# Altium schematic sheet RS232 Interface.SchDoc
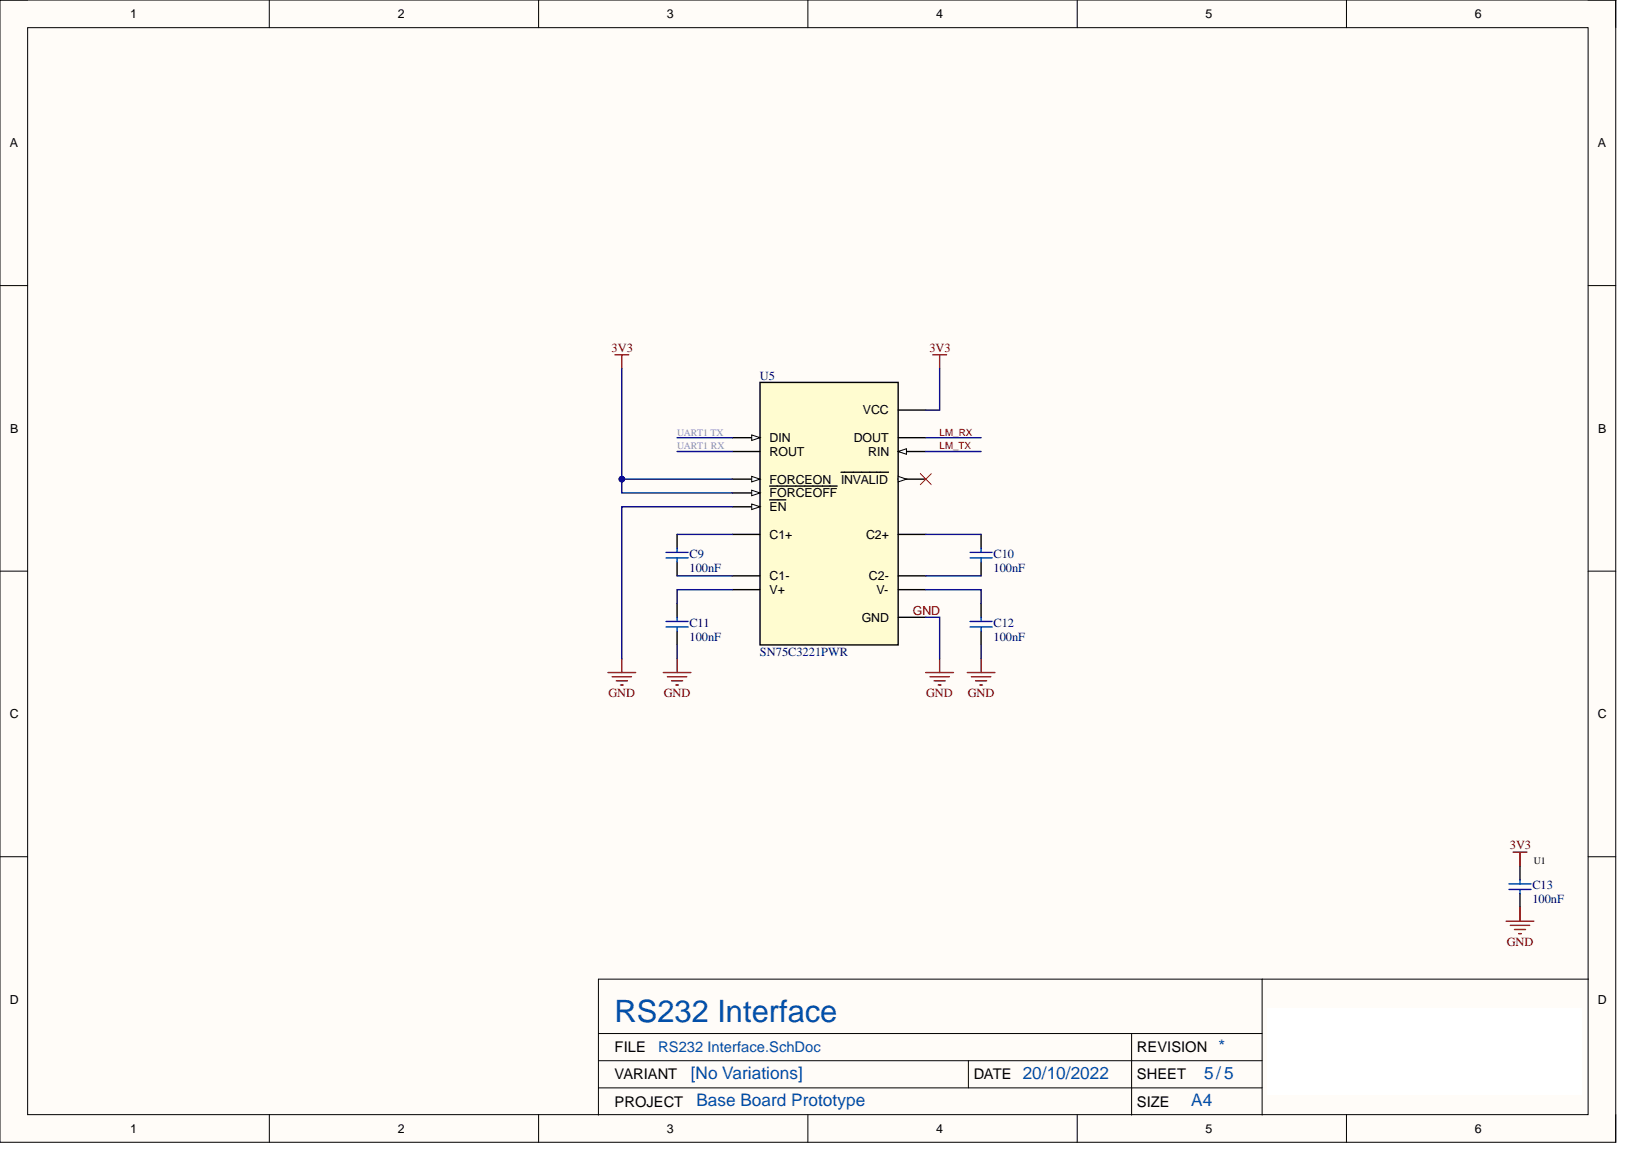
[diagram p0: RS232 Interface.SchDoc]

## Parts
C9  value 100nF  Capacitance: 100nF  [FP-GRM188-0_1-e0_2_0_5-IPC_B]  (hidden comment: C0603-100nF-100V-10%-X7R)
C10  value 100nF  Capacitance: 100nF  [FP-GRM188-0_1-e0_2_0_5-IPC_B]  (hidden comment: C0603-100nF-100V-10%-X7R)
C11  value 100nF  Capacitance: 100nF  [FP-GRM188-0_1-e0_2_0_5-IPC_B]  (hidden comment: C0603-100nF-100V-10%-X7R)
C12  value 100nF  Capacitance: 100nF  [FP-GRM188-0_1-e0_2_0_5-IPC_B]  (hidden comment: C0603-100nF-100V-10%-X7R)
C13  value 100nF  Capacitance: 100nF  [FP-GRM188-0_1-e0_2_0_5-IPC_B]  (hidden comment: C0603-100nF-100V-10%-X7R)
U5  SN75C3221PWR  [PW0016A_N]

## Nets
3V3: C13.2 U5.12(FORCEON) U5.15(VCC) U5.16(FORCEOFF)
GND: C11.1 C12.1 C13.1 U5.1(EN) U5.14(GND)
LM_RX: U5.13(DOUT)
LM_TX: U5.8(RIN)
UART1 RX: U5.9(ROUT)
UART1 TX: U5.11(DIN)
NetC9_1: C9.1 U5.4(C1-)
NetC9_2: C9.2 U5.2(C1+)
NetC10_1: C10.1 U5.6(C2-)
NetC10_2: C10.2 U5.5(C2+)
NetC11_2: C11.2 U5.3(V+)
NetC12_2: C12.2 U5.7(V-)

Not connected: U5 10(INVALID)

## Library data of the parts
C9-C13 = CMP-611-0027-15: 0.1µF ±10% 100V Ceramic Capacitor X7R 0603 (1608 Metric); Pick&PlaceFootprint: 0603_CAP; Capacitor Type: Ceramic; Case Code (Imperial): 0603; Case Code (Metric): 1608; Case/Package: 0603; Component Kind: Standard; Construction: Flat; Depth: 0.8mm; Dielectric: X7R; Height: 0.8mm; Lead Free: Lead Free; Length: 1.6mm; Material: Ceramic; Max Operating Temperature: 125°C; Min Operating Temperature: -55°C; Mount: Surface Mount; Package Quantity: 1; Packaging: Tape and Reel; Radiation Hardening: No; REACH SVHC: No SVHC; RoHS: Yes; RoHS Compliant: Yes; Series: GRM; Size: 0.8mm; Termination: SMD/SMT; Thickness: 0.035inch; Tolerance: 10%; Voltage: 100V; Voltage Rating: 100V; Voltage Rating (DC): 100V; Weight: 0.000222oz; Width: 0.8mm; Library Name: Sainsbury Wellcome Centre
U5 = CMP-930-0000-8: IC RS232 DRVR/RCVR 1-CH 16-TSSOP; Data Rate: 1000Kbps; Logic Function: Transceiver,Receiver; Max Supply Voltage: 5.5V; Min Supply Voltage: 3V; Nominal Supply Current: 1mA; Number of Drivers: 1; Operating Supply Voltage: 5V; Pick&PlaceFootprint: TSSOP-14; Simplex/Duplex: Full Duplex; Case/Package: TSSOP; Component Kind: Standard; Lead Free: Lead Free; Max Operating Temperature: 70°C; Min Operating Temperature: 0°C; Mount: Surface Mount; Number of Pins: 16; Number of Receivers: 1; Number of Transceivers: 1; Number of Transmitters: 1; Operating Supply Current: 1mA; Package Quantity: 1; Packaging: Tape and Reel; PartNumber: SN75C3221PWR; Radiation Hardening: No; REACH SVHC: No SVHC; Weight: 0.002183oz; Library Name: Sainsbury Wellcome Centre
